# Altium schematic sheet LoraModule.SchDoc
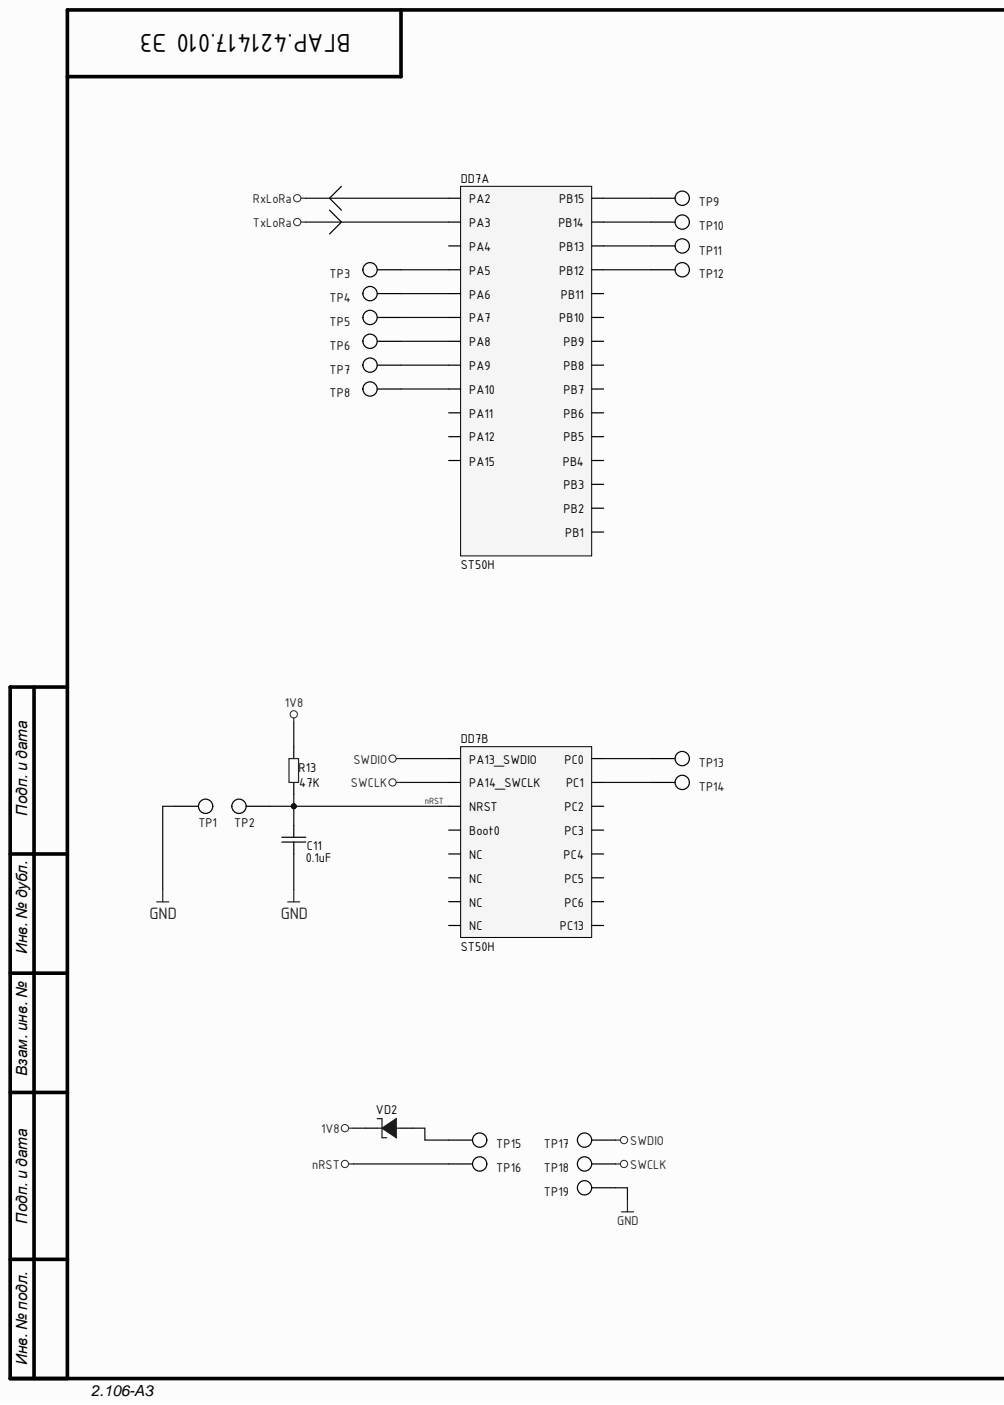
[diagram p0: LoraModule.SchDoc, left]
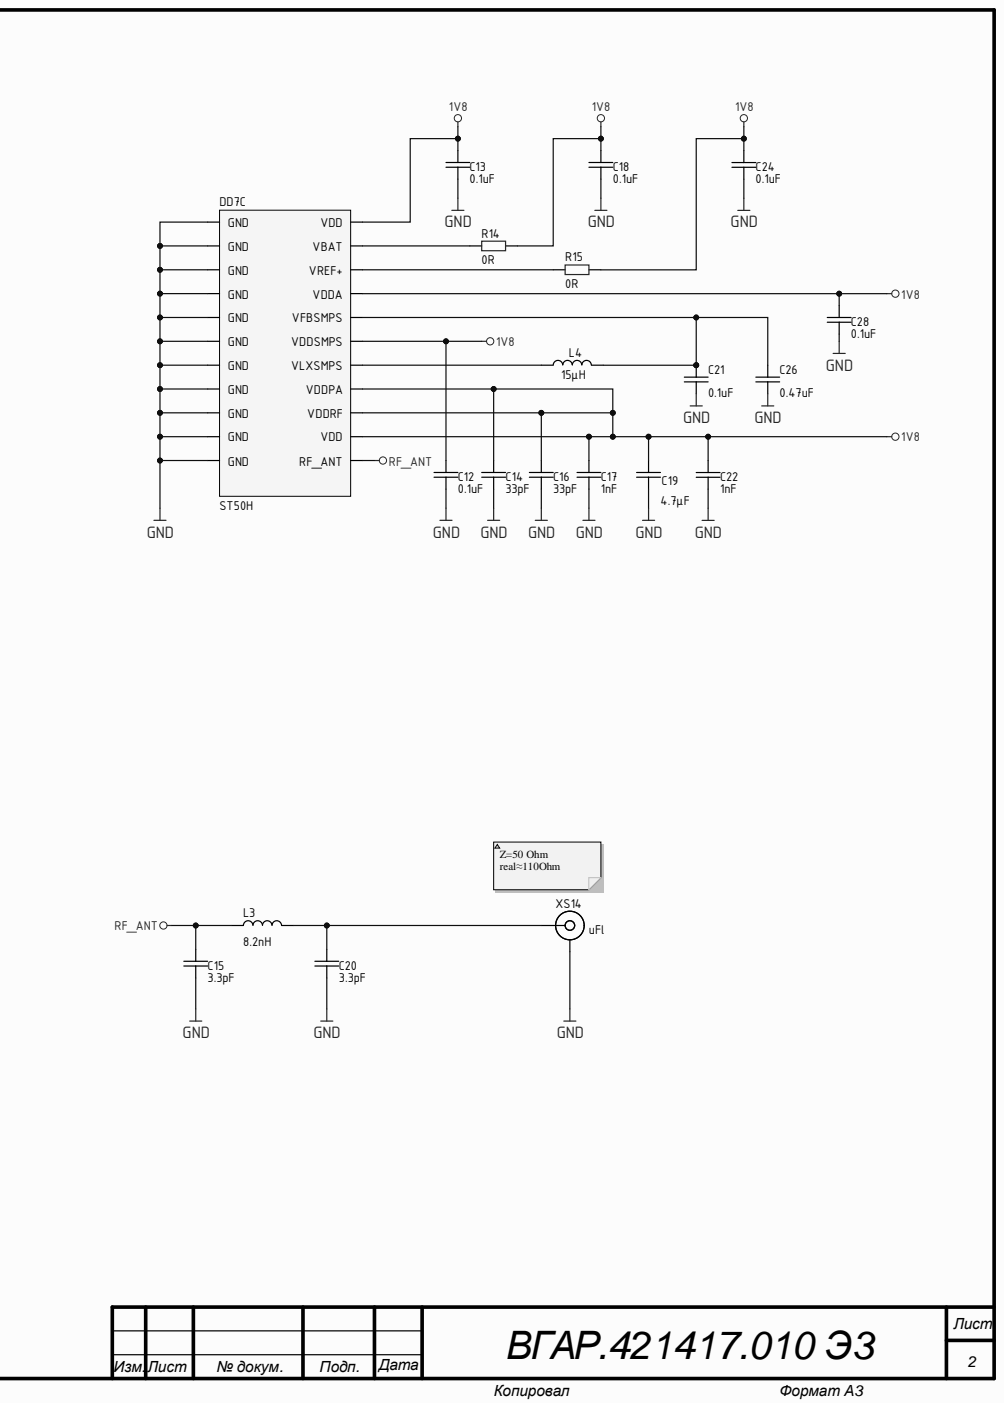
[diagram p1: LoraModule.SchDoc, right]

## Parts
C11  value 0.1uF  [CAP: 0402]  (hidden comment: Ceramic capacitor, 0.1uF, X7R, ±10%, 16V, 0402, -55...+125°C)
C12  value 0.1uF  [CAP: 0402]  (hidden comment: Ceramic capacitor, 0.1uF, X7R, ±10%, 16V, 0402, -55...+125°C)
C13  value 0.1uF  [CAP: 0402]  (hidden comment: Ceramic capacitor, 0.1uF, X7R, ±10%, 16V, 0402, -55...+125°C)
C14  value 33pF  [CAP: 0402]  (hidden comment: Ceramic capacitor, 33pF, X7R, ±10%, 16V, 0402, -55...+125°C)
C15  value 3.3pF  [CAP: 0402]  (hidden comment: Ceramic capacitor, 3.3pF, X7R, ±10%, 16V, 0402, -55...+125°C)
C16  value 33pF  [CAP: 0402]  (hidden comment: Ceramic capacitor, 33pF, X7R, ±10%, 16V, 0402, -55...+125°C)
C17  value 1nF  [CAP: 0402]  (hidden comment: Ceramic capacitor, 1nF, X7R, ±10%, 16V, 0402, -55...+125°C)
C18  value 0.1uF  [CAP: 0402]  (hidden comment: Ceramic capacitor, 0.1uF, X7R, ±10%, 16V, 0402, -55...+125°C)
C19  value 4.7μF  [CAP: 0603]  (hidden comment: Ceramic capacitor, 4.7μF, X7R, ±10%, 16V, 0603, -55...+125°C)
C20  value 3.3pF  [CAP: 0402]  (hidden comment: Ceramic capacitor, 3.3pF, X7R, ±10%, 16V, 0402, -55...+125°C)
C21  value 0.1uF  [CAP: 0402]  (hidden comment: Ceramic capacitor, 0.1uF, X7R, ±10%, 16V, 0402, -55...+125°C)
C22  value 1nF  [CAP: 0402]  (hidden comment: Ceramic capacitor, 1nF, X7R, ±10%, 16V, 0402, -55...+125°C)
C24  value 0.1uF  [CAP: 0402]  (hidden comment: Ceramic capacitor, 0.1uF, X7R, ±10%, 16V, 0402, -55...+125°C)
C26  value 0.47uF  [CAP: 0402]  (hidden comment: Ceramic capacitor, 0.47uF, X7R, ±10%, 16V, 0402, -55...+125°C)
C28  value 0.1uF  [CAP: 0402]  (hidden comment: Ceramic capacitor, 0.1uF, X7R, ±10%, 16V, 0402, -55...+125°C)
DD7  ST50H  [ST50H]  (parts A,B,C)
L3  value 8.2nH  [IND: 0402]  (hidden comment: Fixed inductor, 8.2nH, ±5%, 0402, 200mA, -55...+125°C)
L4  value 15μH  [IND: 0805]  (hidden comment: Fixed inductor, 15μH, ±20%, 0805, 100mA, -55...+125°C)
R13  value 47K  [RES: 0402]  (hidden comment: Resistor film, 47K, ±5%, 0402, 0.063W, -55...+125°C)
R14  value 0R  [RES: 0402]  (hidden comment: Resistor film, 0R, ±5%, 0402, 0.063W, -55...+125°C)
R15  value 0R  [RES: 0402]  (hidden comment: Resistor film, 0R, ±5%, 0402, 0.063W, -55...+125°C)
TP1  Testpoint_HS1mm  [TestPoint(without 3D)]  (value not drawn)
TP2  Testpoint_HS1mm  [TestPoint(without 3D)]  (value not drawn)
TP3  Testpoint_HS1mm  [TestPoint(without hole)]  (value not drawn)
TP4  Testpoint_HS1mm  [TestPoint(without hole)]  (value not drawn)
TP5  Testpoint_HS1mm  [TestPoint(without hole)]  (value not drawn)
TP6  Testpoint_HS1mm  [TestPoint(without hole)]  (value not drawn)
TP7  Testpoint_HS1mm  [TestPoint(without hole)]  (value not drawn)
TP8  Testpoint_HS1mm  [TestPoint(without hole)]  (value not drawn)
TP9  Testpoint_HS1mm  [TestPoint(without hole)]  (value not drawn)
TP10  Testpoint_HS1mm  [TestPoint(without hole)]  (value not drawn)
TP11  Testpoint_HS1mm  [TestPoint(without hole)]  (value not drawn)
TP12  Testpoint_HS1mm  [TestPoint(without hole)]  (value not drawn)
TP13  Testpoint_HS1mm  [TestPoint(without hole)]  (value not drawn)
TP14  Testpoint_HS1mm  [TestPoint(without hole)]  (value not drawn)
TP15  Testpoint_HS1mm  [TestPoint(without hole)]  (value not drawn)
TP16  Testpoint_HS1mm  [TestPoint(without hole)]  (value not drawn)
TP17  Testpoint_HS1mm  [TestPoint(without hole)]  (value not drawn)
TP18  Testpoint_HS1mm  [TestPoint(without hole)]  (value not drawn)
TP19  Testpoint_HS1mm  [TestPoint(without hole)]  (value not drawn)
VD2  Schottky diode, 80V, 500mA, 1V, 15pF, SOD-123, -55...+150°C  [DIODE: SOD523]  (value not drawn)
XS14  CommentText: uFl  [CON: u.Fl]  (hidden comment: Connector u.FL, 50 Ohm, 6GHz)

## Nets
1V8: C12.2 C13.2 C14.2 C16.2 C17.2 C18.2 C19.2 C22.2 C24.2 C28.2 DD7.3(VDD) DD7.7(VDDA) DD7.11(VDDSMPS) DD7.53(VDDPA) DD7.54(VDDRF) DD7.55(VDD) R13.2 R14.1 R15.1 VD2.2
GND: C11.1 C12.1 C13.1 C14.1 C15.1 C16.1 C17.1 C18.1 C19.1 C20.1 C21.1 C22.1 C24.1 C26.2 C28.1 DD7.12(GND) DD7.28(GND) DD7.35(GND) DD7.36(GND) DD7.38(GND) DD7.39(GND) DD7.46(GND) DD7.47(GND) DD7.52(GND) DD7.56(GND) DD7.65(GND) TP1.1 TP19.1 XS14.2
RF_ANT: C15.2 DD7.37(RF_ANT) L3.1
RxLoRa: DD7.29(PA2)
SWCLK: DD7.2(PA14_SWCLK) TP18.1
SWDIO: DD7.1(PA13_SWDIO) TP17.1
TxLoRa: DD7.30(PA3)
nRST: C11.2 DD7.44(NRST) R13.1 TP2.1 TP16.1
NetC20_2: C20.2 L3.2 XS14.1
NetC21_2: C21.2 C26.1 DD7.10(VFBSMPS) L4.2
NetDD7_4: DD7.4(VBAT) R14.2
NetDD7_6: DD7.6(VREF+) R15.2
NetDD7_9: DD7.9(PB15) TP9.1
NetDD7_13: DD7.13(VLXSMPS) L4.1
NetDD7_21: DD7.21(PC0) TP13.1
NetDD7_22: DD7.22(PC1) TP14.1
NetDD7_32: DD7.32(PA5) TP3.1
NetDD7_33: DD7.33(PA6) TP4.1
NetDD7_34: DD7.34(PA7) TP5.1
NetDD7_50: DD7.50(PA9) TP7.1
NetDD7_51: DD7.51(PA8) TP6.1
NetDD7_59: DD7.59(PB12) TP12.1
NetDD7_60: DD7.60(PB13) TP11.1
NetDD7_61: DD7.61(PB14) TP10.1
NetDD7_62: DD7.62(PA10) TP8.1
NetTP15_1: TP15.1 VD2.1

Not connected: DD7 5(PC13) 8(PA15) 14(PB3) 15(PB4) 16(PB5) 17(PB6) 18(PB7) 19(PB8) 20(PB9) 23(PC2) 24(PC3) 25(PC4) 26(PC5) 27(PC6) 31(PA4) 40(NC) 41(NC) 42(NC) 43(Boot0) 45(NC) 48(PB11) 49(PB10) 57(PB1) 58(PB2) 63(PA11) 64(PA12)

## Library data of the parts
C11-C18 C20-C22 C24 C26 C28 = Ceramic_0402: Manufacturer: Yageo; PartNumber: CC0402KRX7R7BB104; Component Kind: Standard; HelpURL: https://www.digikey.com/product-detail/en/yageo/CC0402KRX7R7BB104/311-1338-1-ND/2103122; Library Name: capacitor.IntLib; Package: 0402; Temperature: -55...+125°C; Tolerance: ±10%; TypeCapacitor: Ceramic capacitor; TypeDielectric: X7R; Voltage: 16V
  C11-C13 C18 C21 C24 C28: ValueManufacturerPartNumber: Ceramic capacitor, 0.1uF, X7R, ±10%, 16V, 0402, -55...+125°C
  C14 C16: ValueManufacturerPartNumber: Ceramic capacitor, 33pF, X7R, ±10%, 16V, 0402, -55...+125°C
  C15 C20: ValueManufacturerPartNumber: Ceramic capacitor, 3.3pF, X7R, ±10%, 16V, 0402, -55...+125°C
  C17 C22: ValueManufacturerPartNumber: Ceramic capacitor, 1nF, X7R, ±10%, 16V, 0402, -55...+125°C
  C26: ValueManufacturerPartNumber: Ceramic capacitor, 0.47uF, X7R, ±10%, 16V, 0402, -55...+125°C
C19 = Ceramic_0603: Manufacturer: Yageo; PartNumber: CC0603KRX7R7BB104; Component Kind: Standard; HelpURL: https://www.digikey.com/product-detail/en/yageo/CC0603KRX7R7BB104/311-1088-1-ND/302998; Library Name: capacitor.IntLib; Package: 0603; Temperature: -55...+125°C; Tolerance: ±10%; TypeCapacitor: Ceramic capacitor; TypeDielectric: X7R; ValueManufacturerPartNumber: Ceramic capacitor, 4.7μF, X7R, ±10%, 16V, 0603, -55...+125°C; Voltage: 16V
DD7 = ST50H: LoRa module; Component Kind: Standard; Library Name: ic-rf-transceiver.IntLib
L3 = Inductor_0402: Manufacturer: Murata Electronics; PartNumber: LQG15HSR10J02D; Component Kind: Standard; HelpURL: https://www.digikey.com/product-detail/en/murata-electronics-north-america/LQG15HSR10J02D/490-2635-1-ND/662915; Library Name: inductor.IntLib; MaxCurrent: 200mA; Package: 0402; Temperature: -55...+125°C; Tolerance: ±5%; TypeInductor: Fixed inductor; ValueManufacturerPartNumber: Fixed inductor, 8.2nH, ±5%, 0402, 200mA, -55...+125°C
L4 = Inductor_0805: Manufacturer: Murata Electronics; PartNumber: LQM21FN100M70L; Component Kind: Standard; HelpURL: https://www.digikey.com/product-detail/en/murata-electronics-north-america/LQM21FN100M70L/490-4029-1-ND/1016289; Library Name: inductor.IntLib; MaxCurrent: 100mA; Package: 0805; Temperature: -55...+125°C; Tolerance: ±20%; TypeInductor: Fixed inductor; ValueManufacturerPartNumber: Fixed inductor, 15μH, ±20%, 0805, 100mA, -55...+125°C
R13-R15 = Resistor_0402: Manufacturer: Yageo; PartNumber: RC0402JR-07100RL; Component Kind: Standard; HelpURL: https://www.digikey.com/product-detail/en/yageo/RC0402JR-07100RL/311-100JRCT-ND/729362; Library Name: resistor.IntLib; Package: 0402; Power: 0.063W; Temperature: -55...+125°C; Tolerance: ±5%; TypeResistor: Resistor film
  R13: ValueManufacturerPartNumber: Resistor film, 47K, ±5%, 0402, 0.063W, -55...+125°C
  R14 R15: ValueManufacturerPartNumber: Resistor film, 0R, ±5%, 0402, 0.063W, -55...+125°C
VD2 = Schottky_single: Manufacturer: Diodes Incorporated; PartNumber: BAT54XV2; Component Kind: Standard; Current: 500mA; HelpURL: https://www.digikey.com/product-detail/en/diodes-incorporated/MBR0580S1-7/MBR0580S1-7DICT-ND/5080371; Library Name: diode.IntLib; Package: SOD-123; RecoveryTime: 15pF; Temperature: -55...+150°C; TypeDiode: Schottky diode; ValueManufacturerPartNumber: Schottky diode, 80V, 500mA, 1V, 15pF, SOD-123, -55...+150°C; Voltage: 80V; VoltageDrop: 1V
XS14 = Connector_uFL: Manufacturer: Hirose Electric; PartNumber: U.FL-R-SMT(01); Impedance: 50 Ohm; Component Kind: Standard; Frequency: 6GHz; HelpURL: https://www.digikey.com/product-detail/en/hirose-electric-co-ltd/U.FL-R-SMT-01/H9161-ND/513010; Library Name: connector.IntLib; TypeConnector: Connector u.FL; ValueManufacturerPartNumber: Connector u.FL, 50 Ohm, 6GHz
TP1-TP19 = Testpoint_HS1mm: Component Kind: Standard; Library Name: connector.IntLib
